# Altium schematic sheet ETHERNET.SchDoc
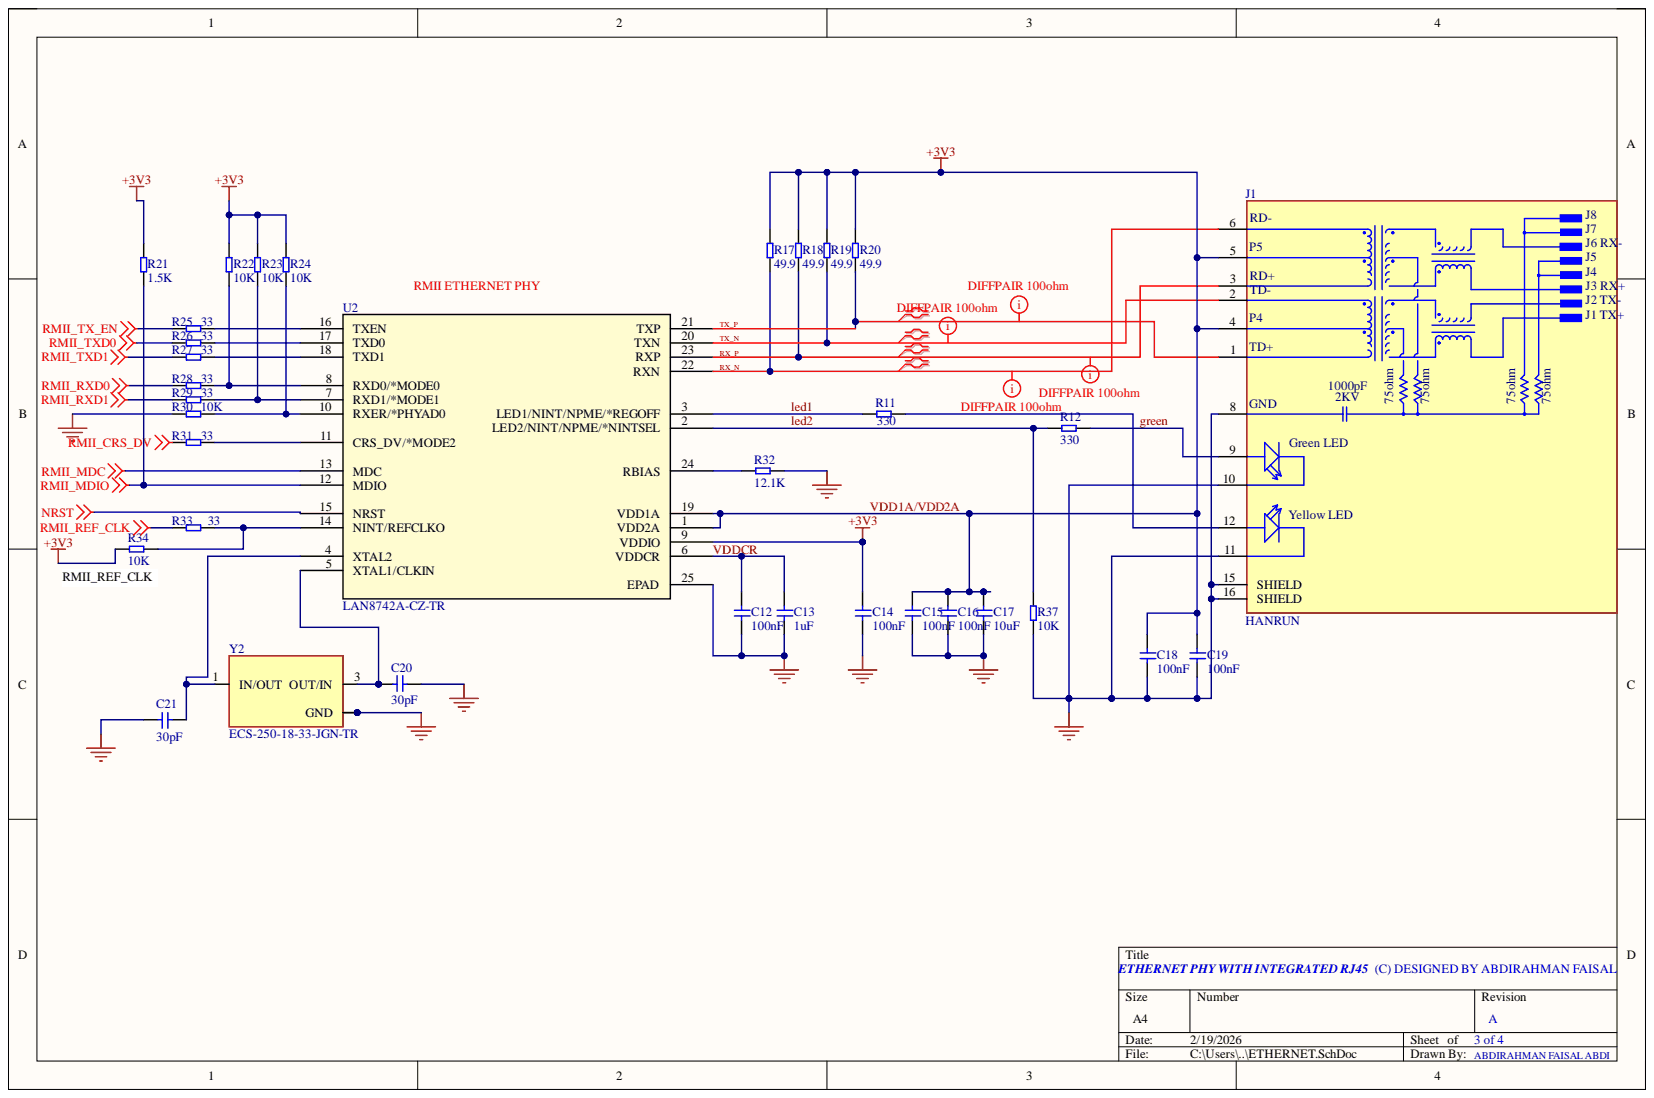
[diagram p0: ETHERNET.SchDoc]

## Parts
C12  100nF  [C0603]
C13  1uF  [C0603]
C14  100nF  [C0603]
C15  100nF  [C0603]
C16  100nF  [C0603]
C17  10uF  [C0603]
C18  100nF  [C0603]
C19  100nF  [C0603]
C20  30pF  [C0603]
C21  30pF  [C0603]
J1  HANRUN  [HANRUN_HR911105A]
R11  330  [R0603]
R12  330  [R0603]
R17  49.9  [R0603]
R18  49.9  [R0603]
R19  49.9  [R0603]
R20  49.9  [R0603]
R21  1.5K  [R0603]
R22  10K  [R0603]
R23  10K  [R0603]
R24  10K  [R0603]
R25  33  [R0603]
R26  33  [R0603]
R27  33  [R0603]
R28  33  [R0603]
R29  33  [R0603]
R30  10K  [R0603]
R31  33  [R0603]
R32  12.1K  [R0603]
R33  33  [R0603]
R34  10K  [R0603]
R37  10K  [R0603]
U2  LAN8742A-CZ-TR  [SQFN24_4X4MC_MCH]
Y2  ECS-250-18-33-JGN-TR  [XTAL_ECS-250-18-33-JGN-TR]

## Nets
GND: C12.1 C13.1 C14.1 C15.1 C16.1 C17.1 C18.1 C19.1 C20.1 C21.1 J1.8 J1.10 J1.11 J1.15(SHIELD) J1.16(SHIELD) R30.1 R32.2 R37.1 U2.25(EPAD) Y2.2(GND) Y2.4
NRST: U2.15(NRST)
RMII_CRS_DV: R31.1
RMII_MDC: U2.13(MDC)
RMII_MDIO: R21.1 U2.12(MDIO)
RMII_REF_CLK: R33.1
RMII_RXD0: R28.1
RMII_RXD1: R29.1
RMII_TX_EN: R25.1
RMII_TXD0: R26.1
RMII_TXD1: R27.1
green: J1.9 R12.1
led1: R11.2 U2.3(LED1/NINT/NPME/*REGOFF)
led2: R12.2 R37.2 U2.2(LED2/NINT/NPME/*NINTSEL)
RX_N: J1.6 R17.1 U2.22(RXN)
RX_P: J1.3 R18.1 U2.23(RXP)
TX_N: J1.2 R19.1 U2.20(TXN)
TX_P: J1.1 R20.1 U2.21(TXP)
VDD1A/VDD2A: C14.2 C15.2 C16.2 C17.2 C18.2 C19.2 J1.4 J1.5 R17.2 R18.2 R19.2 R20.2 R21.2 R22.2 R23.2 R24.2 R34.2 U2.1(VDD2A) U2.9(VDDIO) U2.19(VDD1A)
VDDCR: C12.2 C13.2 U2.6(VDDCR)
NetC20_2: C20.2 U2.5(XTAL1/CLKIN) Y2.3(OUT/IN)
NetC21_2: C21.2 U2.4(XTAL2) Y2.1(IN/OUT)
NetJ1_12: J1.12 R11.1
NetR22_1: R22.1 R28.2 U2.8(RXD0/*MODE0)
NetR23_1: R23.1 R29.2 U2.7(RXD1/*MODE1)
NetR24_1: R24.1 R30.2 U2.10(RXER/*PHYAD0)
NetR25_2: R25.2 U2.16(TXEN)
NetR26_2: R26.2 U2.17(TXD0)
NetR27_2: R27.2 U2.18(TXD1)
NetR31_2: R31.2 U2.11(CRS_DV/*MODE2)
NetR32_1: R32.1 U2.24(RBIAS)
NetR33_2: R33.2 R34.1 U2.14(NINT/REFCLKO)

## Library data of the parts
C12 C14-C16 C18 C19 = CGA3E3X8R1H104K080AD: CAP CER 0.1UF 50V X8R 0603 EPOXY; Manufacturer: TDK; Category: Ceramic Capacitors; Component Kind: Standard; ComponentLink1Description: https://datasheet.ciiva.com/24219/cga3e3x8r1h104k080ad-270768-24219691.pdf?src-supplier=Mouser; ComponentLink1URL: https://datasheet.ciiva.com/24219/cga3e3x8r1h104k080ad-270768-24219691.pdf?src-supplier=Mouser; LCSC part number: C2171928; Library Name: IO.SchLib; Manufacturer Part Number: CGA3E3X8R1H104K080AD; Pricing 1: 1=0.22, 10=0.129, 50=0.0922, 100=0.0693, 500=0.06232, 1000=0.05656 (USD); RoHS: Yes; Stock 1: 30979; Supplier 1: Digikey
C13 = CL10B105KP8NNNC: CAP CER 1UF 10V X7R 0603; Manufacturer: Samsung; Tolerance: 10%; Capacitance: 1uF; Case Code (Imperial): 0603; Case Code (Metric): 1608; Case/Package: 0603; Category: Ceramic Capacitors; Component Kind: Standard; ComponentLink1Description: https://datasheet.ciiva.com/25788/cl10b105kp8nnnc-25788304.pdf?src-supplier=Digikey; ComponentLink1URL: https://datasheet.ciiva.com/25788/cl10b105kp8nnnc-25788304.pdf?src-supplier=Digikey; ComponentLink2Description: https://datasheet.ciiva.com/16396/cl10b105kp8nnnc-16396970.pdf?src-supplier=Future+Electronics; ComponentLink2URL: https://datasheet.ciiva.com/16396/cl10b105kp8nnnc-16396970.pdf?src-supplier=Future+Electronics; ComponentLink3Description: https://datasheet.ciiva.com/26838/getdatasheetpartid-358410-26838426.pdf?src-supplier=Verical; ComponentLink3URL: https://datasheet.ciiva.com/26838/getdatasheetpartid-358410-26838426.pdf?src-supplier=Verical; Construction: Flat; Depth: 0.8mm; Dielectric: X7R; Height: 0.8mm; LCSC part number: C95843; Lead Free: Lead Free; Length: 1.6mm; Library Name: IO.SchLib; Manufacturer Part Number: CL10B105KP8NNNC; Max Operating Temperature: 125°C; Min Operating Temperature: -55°C; Mount: Surface Mount; Package Quantity: 1; Packaging: Tape and Reel; Pricing 1: 1=0.08, 10=0.005 (USD); Radiation Hardening: No; RoHS: Yes; RoHS Compliant: Yes; Series: CL; Stock 1: 5099033; Supplier 1: Digikey; Thickness: 0.035inch; Voltage Rating: 10V; Voltage Rating (DC): 10V; Width: 0.031inch
C17 = GRM188Z71A106KA73D: CAP CER 10UF 10V X7R 0603; Manufacturer: Murata; Material: 4241ConductorMaterial; Tolerance: 10%; Capacitance: 10uF; Case/Package: 345Case; Category: Ceramic Capacitors; Component Kind: Standard; ComponentLink1Description: https://datasheet.octopart.com/GRM188Z71A106KA73D-Murata-datasheet-165579666.pdf?src-supplier=TME; ComponentLink1URL: https://datasheet.octopart.com/GRM188Z71A106KA73D-Murata-datasheet-165579666.pdf?src-supplier=TME; Height: 1mm; LCSC part number: C2959727; Length: 1.6mm; Library Name: IO.SchLib; Manufacturer Part Number: GRM188Z71A106KA73D; Max Operating Temperature: 125°C; Min Operating Temperature: -55°C; Pricing 1: 1=0.28, 10=0.166, 50=0.1204, 100=0.0952, 500=0.08694, 1000=0.07952 (USD); RoHS: Yes; RoHS Compliant: Yes; Stock 1: 55600; Supplier 1: Digikey; Thickness: 800um; Voltage Rating: 10V; Width: 800um
C20 C21 = AC0603JRNPO9BN300: CAP CER 30PF 50V C0G/NPO 0603; Manufacturer: Yageo; Category: Ceramic Capacitors; Component Kind: Standard; LCSC part number: C2191217; Library Name: IO.SchLib; Manufacturer Part Number: AC0603JRNPO9BN300; Pricing 1: 1=0.11, 10=0.061, 50=0.0424, 100=0.035, 500=0.02706, 1000=0.02316 (USD); RoHS: No; Stock 1: 2686; Supplier 1: Digikey
R11 R12 = RC0603FR-07330RL: RES 330 OHM 1% 1/10W 0603; Manufacturer: Yageo; Capacitance: 47uF; ESR (Equivalent Series Resistance): 800mR; Max Power Dissipation: 100mW; Resistance: 330R; Temperature Coefficient: 100ppm/°C; Voltage Rating: 50V; Case Code (Imperial): 0603; Case Code (Metric): 1608; Case/Package: 0603; Category: Chip SMD Resistors; Component Kind: Standard; Depth: 0.8mm; Features: Moisture Resistant; Height: 0.45mm; LCSC part number: C105881; Lead Free: 5697LeadFree; Length: 1.6mm; Library Name: IO.SchLib; Manufacturer Part Number: RC0603FR-07330RL; Max Operating Temperature: 155°C; Min Operating Temperature: -55°C; Number of Pins: 2; Number of Terminations: 2; Package Quantity: 1; Packaging: Tape and Reel; Power Rating: 100mW; Pricing 1: 1=0.1, 10=0.009, 100=0.0082, 1000=0.00612, 2500=0.00461 (USD); Reach SVHC Compliant: No; Resistance Tolerance: 1%; Resistor Type: Thick Film; RoHS: Yes; RoHS Compliant: Yes; Series: RC; Size Code: 0603; Stock 1: 425796; Supplier 1: Digikey; Technology: THICK FILM; Termination: SMD/SMT; Tolerance: 1%; Voltage Rating (AC): 50V; Voltage Rating (DC): 50V; Width: 0.8mm
R17-R20 = RE0603FRE0749R9L: RES SMD 49.9 OHM 1% 1/10W 0603; Manufacturer: Yageo; Max Power Dissipation: 100mW; Resistance: 49.9R; Temperature Coefficient: 50ppm/°C; Case/Package: 0603; Category: Chip SMD Resistors; Component Kind: Standard; ComponentLink1Description: http://www.yageo.com/NewPortal/yageodocoutput?fileName=/pdf/R-Chip/PYu-RE_105_RoHS_L_4.pdf&src-supplier=Digikey; ComponentLink1URL: http://www.yageo.com/NewPortal/yageodocoutput?fileName=/pdf/R-Chip/PYu-RE_105_RoHS_L_4.pdf&src-supplier=Digikey; ComponentLink2Description: https://datasheet.octopart.com/RE0603FRE0749R9L-Yageo-datasheet-181784607.pdf?src-supplier=Newark; ComponentLink2URL: https://datasheet.octopart.com/RE0603FRE0749R9L-Yageo-datasheet-181784607.pdf?src-supplier=Newark; Features: Moisture Resistant; Height: 0.022inch; LCSC part number: C859439; Length: 0.063inch; Library Name: IO.SchLib; Manufacturer Part Number: RE0603FRE0749R9L; Max Operating Temperature: 155°C; Min Operating Temperature: -55°C; Number of Terminations: 2; Packaging: Tape and Reel; Pricing 1: 1=0.1, 10=0.015, 100=0.0133, 1000=0.00714 (USD); RoHS: Yes; RoHS Compliant: Yes; Series: RE; Stock 1: 13124; Supplier 1: Digikey; Tolerance: 1%; Width: 0.032inch
R21 = RC0603FR-131K5L: RES 1.5K OHM 1% 1/10W 0603; Manufacturer: Yageo; Category: Chip SMD Resistors; Component Kind: Standard; ComponentLink1Description: http://www.mouser.com/ds/2/447/RC0603-272842.pdf?src-supplier=Mouser; ComponentLink1URL: http://www.mouser.com/ds/2/447/RC0603-272842.pdf?src-supplier=Mouser; ComponentLink2Description: https://datasheet.octopart.com/RC0603FR-131K5L-Yageo-datasheet-164759695.pdf?src-supplier=Future+Electronics; ComponentLink2URL: https://datasheet.octopart.com/RC0603FR-131K5L-Yageo-datasheet-164759695.pdf?src-supplier=Future+Electronics; ComponentLink3Description: http://www.yageo.com/pdf/PYu-RC0603_51_RoHS_L_4.pdf?src-supplier=Avnet; ComponentLink3URL: http://www.yageo.com/pdf/PYu-RC0603_51_RoHS_L_4.pdf?src-supplier=Avnet; ComponentLink4Description: https://datasheet.octopart.com/RC0603FR-131K5L-Yageo-Group-datasheet-189192902.pdf?src-supplier=TME; ComponentLink4URL: https://datasheet.octopart.com/RC0603FR-131K5L-Yageo-Group-datasheet-189192902.pdf?src-supplier=TME; LCSC part number: C4093535; Lead Free: 5697LeadFree; Library Name: IO.SchLib; Manufacturer Part Number: RC0603FR-131K5L; Pricing 1: 1=0.1, 10=0.009, 100=0.0071, 1000=0.0051, 2500=0.00408, 5000=0.00306, 10000=0.00204 (USD); RoHS: Yes; Stock 1: 66698; Supplier 1: Digikey
R22-R24 R30 R34 R37 = AC0201FR-0710KL: SMD Chip Resistor, 10 kOhm, ± 1%, 50 mW, 0201 [0603 Metric], Thick Film, General Purpose; Manufacturer: Yageo; Max Power Dissipation: 50mW; Resistance: 10kR; Temperature Coefficient: 200ppm/°C; Case Code (Imperial): 0201; Case Code (Metric): 0603; Case/Package: 0201; Category: Chip SMD Resistors; Component Kind: Standard; ComponentLink1Description: https://datasheet.octopart.com/AC0201FR-0710KL-Yageo-datasheet-166720450.pdf?src-supplier=Future+Electronics; ComponentLink1URL: https://datasheet.octopart.com/AC0201FR-0710KL-Yageo-datasheet-166720450.pdf?src-supplier=Future+Electronics; ComponentLink2Description: http://www.yageo.com/NewPortal/yageodocoutput?fileName=/pdf/R-Chip/PYu-AC_51_RoHS_L_6.pdf&src-supplier=Digikey; ComponentLink2URL: http://www.yageo.com/NewPortal/yageodocoutput?fileName=/pdf/R-Chip/PYu-AC_51_RoHS_L_6.pdf&src-supplier=Digikey; Features: Moisture Resistant,Automotive AEC-Q200; Height: 0.01inch; LCSC part number: C144833; Length: 0.024inch; Library Name: IO.SchLib; Manufacturer Part Number: AC0201FR-0710KL; Max Operating Temperature: 155°C; Min Operating Temperature: -55°C; Number of Terminations: 2; Packaging: Cut Tape; Pricing 1: 1=0.1, 10=0.012, 100=0.0092, 1000=0.00714, 2500=0.00612 (USD); RoHS: Yes; RoHS Compliant: Yes; Series: AC; Stock 1: 68849; Supplier 1: Digikey; Tolerance: 1%; Width: 0.012inch
R25-R29 R31 R33 = RC0603FR-0733RL: RES 33 OHM 1% 1/10W 0603; Manufacturer: Yageo; Max Power Dissipation: 100mW; Resistance: 33R; Temperature Coefficient: 100ppm/°C; Voltage Rating: 75V; Voltage Rating (AC): 50V; Case Code (Imperial): 0603; Case Code (Metric): 1608; Case/Package: 0603; Category: Chip SMD Resistors; Component Kind: Standard; ComponentLink1Description: https://datasheet.ciiva.com/11808/0900766b80f96399-11808741.pdf?src-supplier=Rs; ComponentLink1URL: https://datasheet.ciiva.com/11808/0900766b80f96399-11808741.pdf?src-supplier=Rs; ComponentLink2Description: https://datasheet.ciiva.com/7660/38590-7660792.pdf?src-supplier=Element14; ComponentLink2URL: https://datasheet.ciiva.com/7660/38590-7660792.pdf?src-supplier=Element14; ComponentLink3Description: https://datasheet.ciiva.com/46395/443971-46395007.pdf?src-supplier=Element14; ComponentLink3URL: https://datasheet.ciiva.com/46395/443971-46395007.pdf?src-supplier=Element14; ComponentLink4Description: https://datasheet.ciiva.com/2453/pyu-r-int-thick-7-2453953.pdf?src-supplier=Digikey; ComponentLink4URL: https://datasheet.ciiva.com/2453/pyu-r-int-thick-7-2453953.pdf?src-supplier=Digikey; ComponentLink5Description: https://datasheet.ciiva.com/2453/pyu-r-int-thick-7-2453954.pdf?src-supplier=Digikey; ComponentLink5URL: https://datasheet.ciiva.com/2453/pyu-r-int-thick-7-2453954.pdf?src-supplier=Digikey; ComponentLink6Description: https://datasheet.ciiva.com/2453/pyu-r-int-thick-7-2453952.pdf?src-supplier=Digikey; ComponentLink6URL: https://datasheet.ciiva.com/2453/pyu-r-int-thick-7-2453952.pdf?src-supplier=Digikey; ComponentLink7Description: https://datasheet.ciiva.com/7746/443971-7746334.pdf?src-supplier=Element14; ComponentLink7URL: https://datasheet.ciiva.com/7746/443971-7746334.pdf?src-supplier=Element14; ComponentLink8Description: https://datasheet.ciiva.com/7746/443971-7746335.pdf?src-supplier=Element14; ComponentLink8URL: https://datasheet.ciiva.com/7746/443971-7746335.pdf?src-supplier=Element14; ComponentLink9Description: https://datasheet.ciiva.com/15189/getdatasheetpartid-326673-15189708.pdf?src-supplier=Verical; ComponentLink9URL: https://datasheet.ciiva.com/15189/getdatasheetpartid-326673-15189708.pdf?src-supplier=Verical; Construction: Rectangular; Depth: 0.8mm; Features: Moisture Resistant; Height: 0.45mm; LCSC part number: C108661; Lead Free: 5697LeadFree; Lead Pitch: 0.3mm; Length: 1.6mm; Library Name: IO.SchLib; Manufacturer Part Number: RC0603FR-0733RL; Max Operating Temperature: 155°C; Military Standard: Not; Min Operating Temperature: -55°C; Number of Pins: 2; Number of Terminations: 2; Package Quantity: 1; Packaging: Tape and Reel; Power Rating: 100mW; Pricing 1: 1=0.1, 10=0.011, 100=0.0082, 1000=0.0051, 2500=0.00461 (USD); Reach SVHC Compliant: No; Resistance Tolerance: 1%; Resistor Type: Thick Film; RoHS: Yes; RoHS Compliant: Yes; Series: RC; Size Code: 0603; Stock 1: 100391; Supplier 1: Digikey; Technology: THICK FILM; Termination: SMD/SMT; Tolerance: 1%; Voltage Rating (DC): 50V; Weight: 7.1E-05oz; Width: 0.8mm
R32 = RC0603FR-0712K1L: RES 12.1K OHM 1% 1/10W 0603; Manufacturer: Yageo; Max Power Dissipation: 100mW; Resistance: 12.1kR; Temperature Coefficient: 100ppm/°C; Voltage Rating: 50V; Case Code (Imperial): 0603; Case Code (Metric): 1608; Case/Package: 0603; Category: Chip SMD Resistors; Component Kind: Standard; ComponentLink1Description: https://datasheet.ciiva.com/46395/443971-46395022.pdf?src-supplier=Element14; ComponentLink1URL: https://datasheet.ciiva.com/46395/443971-46395022.pdf?src-supplier=Element14; ComponentLink2Description: https://datasheet.ciiva.com/2519/pyu-r-int-thick-7-2519217.pdf?src-supplier=Digikey; ComponentLink2URL: https://datasheet.ciiva.com/2519/pyu-r-int-thick-7-2519217.pdf?src-supplier=Digikey; ComponentLink3Description: https://datasheet.ciiva.com/2519/pyu-r-int-thick-7-2519218.pdf?src-supplier=Digikey; ComponentLink3URL: https://datasheet.ciiva.com/2519/pyu-r-int-thick-7-2519218.pdf?src-supplier=Digikey; ComponentLink4Description: https://datasheet.ciiva.com/12558/rc0603-272842-12558574.pdf?src-supplier=Mouser; ComponentLink4URL: https://datasheet.ciiva.com/12558/rc0603-272842-12558574.pdf?src-supplier=Mouser; ComponentLink5Description: https://datasheet.ciiva.com/15159/getdatasheetpartid-28802-15159207.pdf?src-supplier=Verical; ComponentLink5URL: https://datasheet.ciiva.com/15159/getdatasheetpartid-28802-15159207.pdf?src-supplier=Verical; Construction: Rectangular; Depth: 0.8mm; Features: Moisture Resistant; Height: 0.45mm; LCSC part number: C123417; Lead Free: 5697LeadFree; Length: 1.6mm; Library Name: IO.SchLib; Manufacturer Part Number: RC0603FR-0712K1L; Max Operating Temperature: 155°C; Military Standard: Not; Min Operating Temperature: -55°C; Number of Pins: 2NUMBER; Number of Terminations: 2; Packaging: Tape and Reel; Power Rating: 100mW; Pricing 1: 1=0.1, 10=0.009, 100=0.0071, 1000=0.00612 (USD); Reach SVHC Compliant: No; Resistance Tolerance: 1%; Resistor Type: Thick Film; RoHS: Yes; RoHS Compliant: Yes; Series: RC; Size Code: 0603; Stock 1: 464570; Supplier 1: Digikey; Termination: SMD/SMT; Tolerance: 1%; Voltage Rating (DC): 50V; Width: 0.8mm
U2 = LAN8742A-CZ-TR: IC TRANSCEIVER 1/1 24SQFN; Manufacturer: Microchip; Interface: 1515Interface; Number of Channels: 1NUMBER; Category: Ethernet Interface ICs; Component Kind: Standard; ComponentLink1Description: https://datasheet.ciiva.com/29882/8742a-29882620.pdf?src-supplier=Avnet; ComponentLink1URL: https://datasheet.ciiva.com/29882/8742a-29882620.pdf?src-supplier=Avnet; Height: 1mm; LCSC part number: C621424; Library Name: IO.SchLib; Manufacturer Part Number: LAN8742A-CZ-TR; Pricing 1: 1=1.53, 25=1.28, 100=1.17 (USD); RoHS: Yes; Stock 1: 19050; Supplier 1: Digikey
Y2 = ECS-250-18-33-JGN-TR (2): CRYSTAL 25.0000MHZ 18PF SMD; Manufacturer: ECS International; ESR (Equivalent Series Resistance): 40R; Frequency: 25MHz; Frequency Stability: 30ppm; Frequency Tolerance: 20ppm; Case/Package: SMD/SMT; Category: Crystals; Component Kind: Standard; ComponentLink1Description: https://www.ecsxtal.com/store/pdf/ecx-32.pdf?src-supplier=Digikey; ComponentLink1URL: https://www.ecsxtal.com/store/pdf/ecx-32.pdf?src-supplier=Digikey; ComponentLink2Description: https://datasheet.octopart.com/ECS-250-18-33-JGN-TR-ECS-International-datasheet-140089731.pdf?src-supplier=Future+Electronics; ComponentLink2URL: https://datasheet.octopart.com/ECS-250-18-33-JGN-TR-ECS-International-datasheet-140089731.pdf?src-supplier=Future+Electronics; ComponentLink3Description: https://datasheet.octopart.com/ECS-250-18-33-JGN-TR-ECS-International-datasheet-17018741.pdf?src-supplier=Electro+Sonic; ComponentLink3URL: https://datasheet.octopart.com/ECS-250-18-33-JGN-TR-ECS-International-datasheet-17018741.pdf?src-supplier=Electro+Sonic; ComponentLink4Description: https://datasheet.octopart.com/ECS-250-18-33-JGN-TR-ECS-International-datasheet-179230830.pdf?src-supplier=Master+Electronics; ComponentLink4URL: https://datasheet.octopart.com/ECS-250-18-33-JGN-TR-ECS-International-datasheet-179230830.pdf?src-supplier=Master+Electronics; Library Name: IO.SchLib; Load Capacitance: 18pF; Manufacturer Part Number: ECS-250-18-33-JGN-TR; Max Operating Temperature: 85°C; Min Operating Temperature: -40°C; Mount: Surface Mount; Packaging: Cut Tape; Pricing 1: 1=0.41, 10=0.355, 25=0.336, 50=0.3222, 100=0.3089, 250=0.29208, 500=0.28 (USD); RoHS: Yes; RoHS Compliant: Yes; Series: ECX-32; Stock 1: 39748; Supplier 1: Digikey
J1 = HANRUN: Component Kind: Standard; Library Name: arfaisal.IntLib
